# Altium schematic sheet ST25R3911B.SchDoc
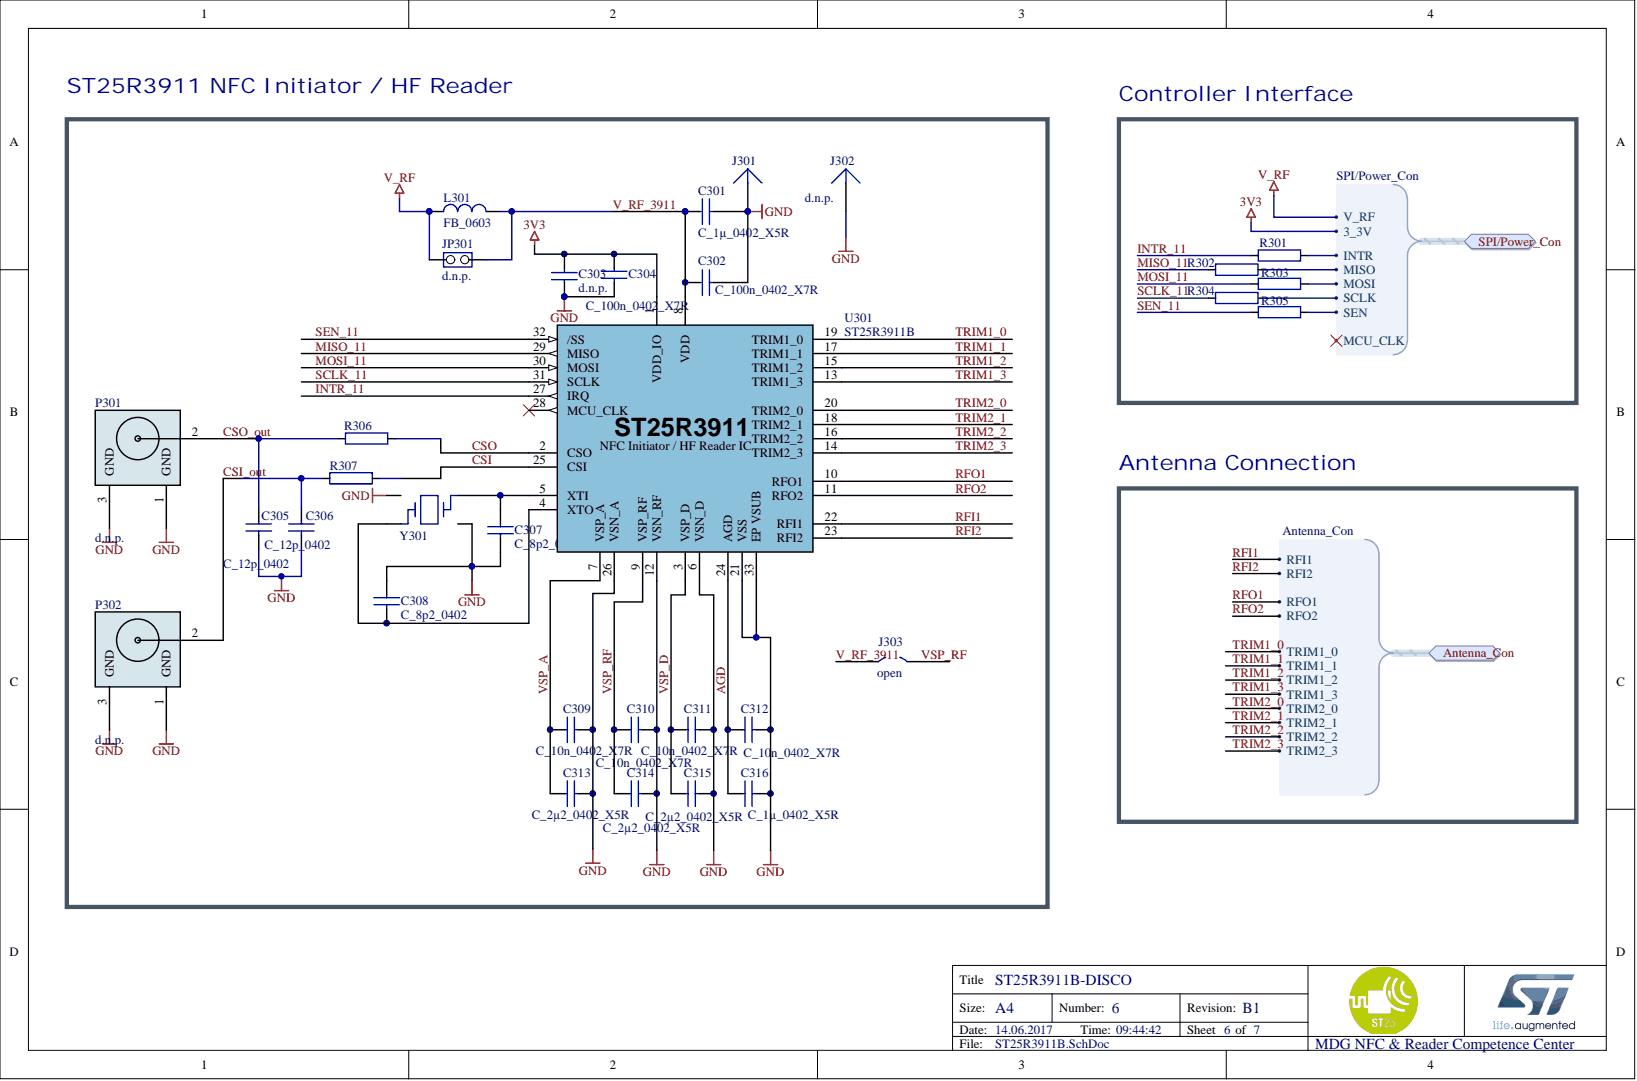
[diagram p0: ST25R3911B.SchDoc]

## Parts
C301  C_1µ_0402_X5R  [C0402]
C302  C_100n_0402_X7R  [C0402]
C303  d.n.p.  [C0402]
C304  C_100n_0402_X7R  [C0402]
C305  C_12p_0402  [C0402]
C306  C_12p_0402  [C0402]
C307  C_8p2_0402  [C0402]
C308  C_8p2_0402  [C0402]
C309  C_10n_0402_X7R  [C0402]
C310  C_10n_0402_X7R  [C0402]
C311  C_10n_0402_X7R  [C0402]
C312  C_10n_0402_X7R  [C0402]
C313  C_2µ2_0402_X5R  [C0402]
C314  C_2µ2_0402_X5R  [C0402]
C315  C_2µ2_0402_X5R  [C0402]
C316  C_1µ_0402_X5R  [C0402]
J301  d.n.p.  [PIN1]  (value not drawn)
J302  d.n.p.  [PIN1]
J303  open  [JP2_SMD_open]
JP301  d.n.p.  [SMD H2]
L301  FB_0603  [L0603]
P301  d.n.p.  [U FL Socket - HRS - Surface Mount]
P302  d.n.p.  [U FL Socket - HRS - Surface Mount]
R301  220R  [R0402]  (value not drawn)
R302  220R  [R0402]  (value not drawn)
R303  220R  [R0402]  (value not drawn)
R304  220R  [R0402]  (value not drawn)
R305  220R  [R0402]  (value not drawn)
R306  1k  [R0402]  (value not drawn)
R307  1k  [R0402]  (value not drawn)
U301  ST25R3911B  [ST25R3911B-QFN32_5X5_0.5]
Y301  27,12MHz Crystal  [XRCGB_F_MN]  (value not drawn)

## Ports
Antenna_Con, Antenna_Con.RFI1, Antenna_Con.RFI2, Antenna_Con.RFO1, Antenna_Con.RFO2, Antenna_Con.TRIM1_0, Antenna_Con.TRIM1_1, Antenna_Con.TRIM1_2, Antenna_Con.TRIM1_3, Antenna_Con.TRIM2_0, Antenna_Con.TRIM2_1, Antenna_Con.TRIM2_2, Antenna_Con.TRIM2_3, SPI/Power_Con, SPI/Power_Con.3_3V, SPI/Power_Con.INTR, SPI/Power_Con.MCU_CLK, SPI/Power_Con.MISO, SPI/Power_Con.MOSI, SPI/Power_Con.SCLK, SPI/Power_Con.SEN, SPI/Power_Con.V_RF

## Nets
GND: C301.2 C302.2 C303.1 C304.2 C305.2 C306.2 C307.2 C308.1 C309.2 C310.2 C311.2 C312.2 C313.2 C314.2 C315.2 C316.2 J301.1 J302.1 P301.1 P301.3 P302.1 P302.3 U301.6(VSN_D) U301.12(VSN_RF) U301.21(VSS) U301.26(VSN_A) U301.33(EP_VSUB) Y301.2 Y301.4
3V3: C303.2 C304.1 U301.1(VDD_IO) ; port SPI/Power_Con
AGD: C312.1 C316.1 U301.24(AGD)
CSI: R307.1 U301.25(CSI)
CSI_out: C306.1 P302.2 R307.2
CSO: R306.1 U301.2(CSO)
CSO_out: C305.1 P301.2 R306.2
INTR_11: R301.2 U301.27(IRQ)
MISO_11: R302.2 U301.29(MISO)
MOSI_11: R303.2 U301.30(MOSI)
RFI1: U301.22(RFI1) ; port Antenna_Con
RFI2: U301.23(RFI2) ; port Antenna_Con
RFO1: U301.10(RFO1) ; port Antenna_Con
RFO2: U301.11(RFO2) ; port Antenna_Con
SCLK_11: R304.2 U301.31(SCLK)
SEN_11: R305.2 U301.32(/SS)
TRIM1_0: U301.19(TRIM1_0) ; port Antenna_Con
TRIM1_1: U301.17(TRIM1_1) ; port Antenna_Con
TRIM1_2: U301.15(TRIM1_2) ; port Antenna_Con
TRIM1_3: U301.13(TRIM1_3) ; port Antenna_Con
TRIM2_0: U301.20(TRIM2_0) ; port Antenna_Con
TRIM2_1: U301.18(TRIM2_1) ; port Antenna_Con
TRIM2_2: U301.16(TRIM2_2) ; port Antenna_Con
TRIM2_3: U301.14(TRIM2_3) ; port Antenna_Con
V_RF: JP301.1 L301.2 ; port SPI/Power_Con
V_RF_3911: C301.1 C302.1 J303.1 JP301.2 L301.1 U301.8(VDD)
VSP_A: C309.1 C313.1 U301.7(VSP_A)
VSP_D: C311.1 C315.1 U301.3(VSP_D)
VSP_RF: C310.1 C314.1 J303.2 U301.9(VSP_RF)
NetC307_1: C307.1 U301.5(XTI) Y301.1
NetC308_2: C308.2 U301.4(XTO) Y301.3
NetR301_1: R301.1 ; port SPI/Power_Con
NetR302_1: R302.1 ; port SPI/Power_Con
NetR303_1: R303.1 ; port SPI/Power_Con
NetR304_1: R304.1 ; port SPI/Power_Con
NetR305_1: R305.1 ; port SPI/Power_Con
N00132: ; port SPI/Power_Con

Not connected: U301 28(MCU_CLK)

## Library data of the parts
C301 C316 = C_1µ_0402_X5R: 1u_X5R; Manufacturer: MURATA; Manufacturer Part Number: GRM155R61C105KA12D; 2nd Source: 0402, 1 µF, 16 V, ± 10%, X5R,; Component Kind: Standard; Library Name: Schlib1.SchLib; Supplier 1: Farnell; Supplier Part Number 1: 2362093
C302 C304 = C_100n_0402_X7R: 100n_X7R; Manufacturer: MULTICOMP; Manufacturer Part Number: MC0402X104K100CT; 2nd Source: 0402, 0.1 µF, 10 V, ± 10%, X5R,; Component Kind: Standard; Library Name: Schlib1.SchLib; Supplier 1: Farnell; Supplier Part Number 1: 1759380
C303 = C_0402: Component Kind: Standard; Library Name: PASSIVE_082011.IntLib
C305 C306 = C_12p_0402: 12p_COG; Manufacturer: MURATA; Manufacturer Part Number: GRM1555C1H120GA01D; 2nd Source: 12pF ±2% 50V  C0G, NP0 0402; Component Kind: Standard; Library Name: Schlib1.SchLib; Supplier 1: Digi-Key; Supplier Part Number 1: 490-6197-1-ND
C307 C308 = C_8p2_0402: 8p2_COG; Manufacturer: MURATA; Manufacturer Part Number: GRM1555C1H8R2CA01D; 2nd Source: 0402, 8.2 pF, 50 V, ± 0.25pF, C0G / NP0; Component Kind: Standard; Library Name: Schlib1.SchLib; Supplier 1: Farnell; Supplier Part Number 1: 1828874
C309-C312 = C_10n_0402_X7R: 10n_x7R; Manufacturer: AVX; Manufacturer Part Number: 04023C103KAT2A; 2nd Source: 0402, 0.01 µF, 25 V, ± 10%, X7R; Component Kind: Standard; Library Name: Schlib1.SchLib; Supplier 1: Farnell; Supplier Part Number 1: 1327624
C313-C315 = C_2µ2_0402_X5R: 2u2_X5R; Manufacturer: MURATA; Manufacturer Part Number: GRM155R60J225ME15D; 2nd Source: 0402, 2.2 µF, 6.3 V, ± 20%, X5R; Component Kind: Standard; Library Name: Schlib1.SchLib; Supplier 1: Farnell; Supplier Part Number 1: 2362088
J301 J302 = Plug: Component Kind: Standard; Description: Plug; LatestRevisionDate: 17-Jul-2002; LatestRevisionNote: Re-released for DXP Platform.; Library Name: Miscellaneous Connectors.IntLib; Signal Integrity: Connector; Publisher: Altium Limited
J303 = solder_jumper_open: Component Kind: Net Tie; Library Name: Schlib1.SchLib
JP301 = JP2: Component Kind: Standard; Library Name: MB1136.IntLib
L301 = FB_0603: Manufacturer: MURATA; Manufacturer Part Number: BLM18EG471SN1D; Component Kind: Standard; Library Name: Schlib1.SchLib; Supplier 1: Farnell; Supplier Part Number 1: 1515722
P301 P302 = U FL - Surface Mount: U FL Socket - Surface Mount; Manufacturer: HRS (HIROSE); Manufacturer Part Number: U.FL-R-SMT-1(10); Component_Description: HRS (HIROSE) - U.FL-R-SMT-1(10) - KUPPLUNG, SMT U.FL; Category: Steckverbinder; Component Kind: Standard; ComponentLink1Description: http://www.farnell.com/datasheets/91524.pdf; ComponentLink1URL: http://www.farnell.com/datasheets/91524.pdf; Library Name: AS3911 Eval V1.SCHLIB; Pricing 1: 1=0,387, 10=0,341, 25=0,318, 50=0,285, 100=0,256 (EUR); RoHS: YES; Stock 1: 24257; Supplier 1: Farnell; Supplier Part Number 1: 1688077
R301-R305 = R0402_220R: 220R, 0402; Manufacturer: Yageo; Manufacturer Part Number: RC0402JR-07220RL; 2nd Source: 220 Ohm ±5% 0.063W, 1/16W Chip Resistor 0402; Component Kind: Standard; Library Name: Schlib1.SchLib; Supplier 1: Digi-Key; Supplier Part Number 1: 311-220JRTR-ND
R306 R307 = R0402_1k: 1k, 0402; Manufacturer: Panasonic; Manufacturer Part Number: ERJ2GEJ102X; 2nd Source: 1 kohm, 50 V, 0402, >100 mW, ± 5%; Component Kind: Standard; Library Name: Schlib1.SchLib; Supplier 1: Farnell; Supplier Part Number 1: 2059225
U301 = ST25R3911: NFC Initiator / HF Reader IC; Manufacturer: STMicroelectronics; Manufacturer Part Number: ST25R3911B-AQFMCT-ND; ST or Subco proc: delivered by ST; Component Kind: Standard; Library Name: Schlib1.SchLib; Supplier 1: Digi-Key; Supplier Part Number 1: ST25R3911B-AQFMCT-ND
Y301 = Crystal_XRCGB27M12: Manufacturer: MURATA; Manufacturer Part Number: XRCGB27M120F3M00R0; Component Kind: Standard; Library Name: Schlib1.SchLib; Supplier 1: Farnell; Supplier Part Number 1: 1885632
